# Altium schematic sheet [10] - FPGA BANK 0, XADC.SchDoc("[10] - FPGA BANK 0, XADC")
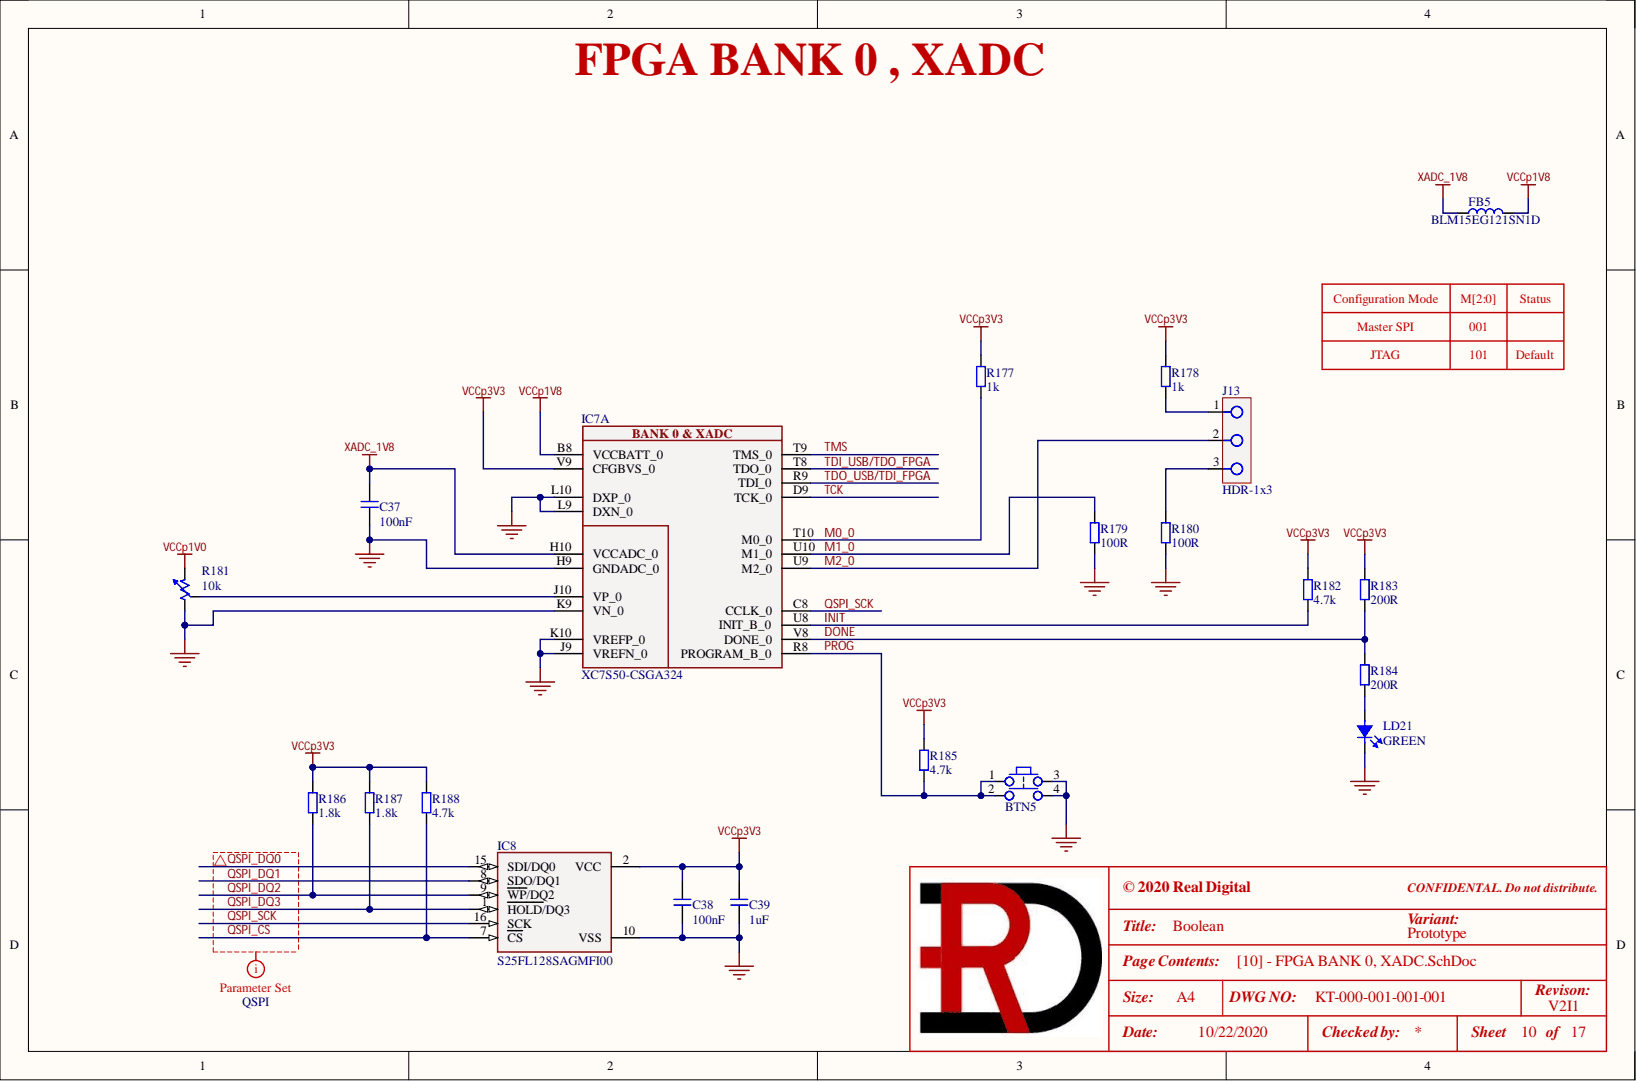
[diagram p0: [10] - FPGA BANK 0, XADC.SchDoc]

## Parts
BTN5  PTA-142  [PTA-142]  (value not drawn)
C37  100nF  [C0402]
C38  100nF  [C0402]
C39  1uF  [C0603]
FB5  BLM15EG121SN1D  [BLM15]
IC7  XC7S50-CSGA324  [CSG324]  (part A)
IC8  S25FL128SAGMFI00  [SOIC-16W]
J13  HDR-1x3  [HDR1X3]
LD21  GREEN  [LD0603_Green]
R177  1k  [R0402]
R178  1k  [R0402]
R179  100R  [R0402]
R180  100R  [R0402]
R181  10k  [R09N]
R182  4.7k  [R0402]
R183  200R  [R0402]
R184  200R  [R0402]
R185  4.7k  [R0402]
R186  1.8k  [R0402]
R187  1.8k  [R0402]
R188  4.7k  [R0402]

## Nets
GND: BTN5.3 BTN5.4 C37.1 C38.1 C39.1 IC7.H9(GNDADC_0) IC7.J9(VREFN_0) IC7.K9(VN_0) IC7.K10(VREFP_0) IC7.L9(DXN_0) IC7.L10(DXP_0) IC8.10(VSS) LD21.K R179.1 R180.1 R181.1
VCCp1V0: R181.3
VCCp1V8: FB5.2 IC7.B8(VCCBATT_0)
VCCp3V3: C38.2 C39.2 IC7.V9(CFGBVS_0) IC8.2(VCC) R177.2 R178.2 R182.2 R183.2 R185.2 R186.2 R187.2 R188.2
XADC_1V8: C37.2 FB5.1 IC7.H10(VCCADC_0)
DONE: IC7.V8(DONE_0) R183.1 R184.2
INIT: IC7.U8(INIT_B_0) R182.1
M0_0: IC7.T10(M0_0) R177.1
M1_0: IC7.U10(M1_0) R179.2
M2_0: IC7.U9(M2_0) J13.2
PROG: BTN5.1 BTN5.2 IC7.R8(PROGRAM_B_0) R185.1
QSPI_CS: IC8.7(CS) R188.1
QSPI_DQ0: IC8.15(SDI/DQ0)
QSPI_DQ1: IC8.8(SDO/DQ1)
QSPI_DQ2: IC8.9(WP/DQ2) R186.1
QSPI_DQ3: IC8.1(HOLD/DQ3) R187.1
QSPI_SCK: IC7.C8(CCLK_0) IC8.16(SCK)
TCK: IC7.D9(TCK_0)
TDI_USB/TDO_FPGA: IC7.T8(TDO_0)
TDO_USB/TDI_FPGA: IC7.R9(TDI_0)
TMS: IC7.T9(TMS_0)
NetIC7_J10: IC7.J10(VP_0) R181.2
NetJ13_1: J13.1 R178.1
NetJ13_3: J13.3 R180.2
NetLD21_A: LD21.A R184.1

## Library data of the parts
BTN5 = Tact Switch: SWITCH TACTILE SPST-NO, 4.50mm x 4.50mm; Manufacturer 1: Pacer Technology Co., Ltd; Manufacturer 2 Part Number: TSXH-2GL; .Creator: RV; C P/N: 153-0059; LoadStatus: Load; Category: Tactile Switches; Component Kind: Standard; Library Name: KT-RealDigital.IntLib; Manufacturer 1 Part Number: PTA-142
C37 C38 = GRM155R71E104KE14J: CAP CER 0.1uF 25V X7R 0402; Manufacturer 1: Murata Electronics; .Checked_PCB: AC; .Creator: RV; C P/N: RD-111-0006; LoadStatus: Load; Tolerance: 10%; Category: Capacitors; Component Kind: Standard; Library Name: KT-RealDigital.IntLib; Manufacturer 1 Part Number: GRM155R71E104KE14J; Temperature Coefficient: X7R; Voltage - Rated: 25V; .Checked_SCH: AC
C39 = GCJ188R71E105KA01D: CAP CER 1uF 25V X7R 0603; Manufacturer 1: Murata Electronics; .Checked_PCB: AC; .Creator: RV; C P/N: 111-0602; LoadStatus: Load; Tolerance: 10%; Category: Capacitors; Component Kind: Standard; Library Name: KT-RealDigital.IntLib; Manufacturer 1 Part Number: GCJ188R71E105KA01D; Temperature Coefficient: X7R; Voltage - Rated: 25V; .Checked_SCH: AC
FB5 = BLM15EG121SN1D: FERRITE BEAD 120 OHM 0402 1LN; Manufacturer 1: Murata Electronics; .Checked_PCB: AC; .Creator: RV; C P/N: 131-0493; LoadStatus: Load; Category: Ferrite Beads; Component Kind: Standard; Library Name: KT-RealDigital.IntLib; Manufacturer 1 Part Number: BLM15EG121SN1D; .Checked_SCH: AC
IC7 = XC7S50-CSGA324: FPGA 210 I/O 324CSBGA; Manufacturer 1: Xilinx; .Creator: RV; C P/N: RD-126-0003-CD; LoadStatus: Load; Category: IC; Component Kind: Standard; Library Name: KT-RealDigital.IntLib; Manufacturer 1 Part Number: XC7S50-CSGA324
IC8 = S25FL128SAGMFI00: IC FLASH 128M SPI 133MHZ 16SOIC; Manufacturer 1: Cypress Semiconductor Corp; .Checked_PCB: AC; .Creator: RV; C P/N: 126-0862; LoadStatus: Load; Category: IC; Component Kind: Standard; Library Name: KT-RealDigital.IntLib; Manufacturer 1 Part Number: S25FL128SAGMFI00; .Checked_SCH: AC
J13 = HDR-1x3: Header 2.54mm, 1x3; Manufacturer 1: Centronic Precision Electronic; Manufacturer 1 Part Number: H6-80-03; .Checked_PCB: AC; .Creator: RV; C P/N: 163-0035; LoadStatus: Load; Category: Header; Component Kind: Standard; Library Name: KT-RealDigital.IntLib; .Checked_SCH: AC
LD21 = LED_GREEN: LED GREEN 0603; Manufacturer 1: Everlight Electronics CO., LTD; Manufacturer 1 Part Number: 19-21SYGC/S530-E4/TR8; .Checked_PCB: AC; .Creator: RV; C P/N: 121-0006; LoadStatus: Load; Category: Led; Component Kind: Standard; Library Name: KT-RealDigital.IntLib; .Checked_SCH: AC
R177 R178 = RC0402JR-071KP: RES SMD 1K OHM 5% 1/16W 0402; Manufacturer 1: Yageo; .Checked_PCB: AC; .Creator: RV; C P/N: 101-0050; LoadStatus: Load; Category: Resistors; Component Kind: Standard; Library Name: KT-RealDigital.IntLib; Manufacturer 1 Part Number: RC0402JR-071KP; Tolerance: 5%; .Checked_SCH: AC
R179 R180 = RC0402JR-07100RL: RES SMD 100 OHM 5% 1/16W 0402; Manufacturer 1: Yageo; .Checked_PCB: AC; .Creator: RV; C P/N: 101-0035; LoadStatus: Load; Category: Resistors; Component Kind: Standard; Library Name: KT-RealDigital.IntLib; Manufacturer 1 Part Number: RC0402JR-07100RL; Tolerance: 5%; .Checked_SCH: AC
R181 = R09N-B10K: POTENTIOMETER 10k, R09N-B10K/10φX1.8T; Manufacturer 1: Song Huei Electric CO. LTD; .Creator: RV; C P/N: 101-0210-1; LoadStatus: Load; Category: Potentiometer; Component Kind: Standard; Library Name: KT-RealDigital.IntLib; Manufacturer 1 Part Number: R09N-B10K/10φX1.8T
R182 R185 R188 = RC0402FR-074K7P: RES SMD 4.7K OHM 1% 1/16W 0402; Manufacturer 1: Yageo; .Checked_PCB: AC; .Creator: RV; C P/N: 101-0129; LoadStatus: Load; Category: Resistors; Component Kind: Standard; Library Name: KT-RealDigital.IntLib; Manufacturer 1 Part Number: RC0402FR-074K7P; Tolerance: 1%; .Checked_SCH: AC
R183 R184 = RC0402JR-07200RL: RES SMD 200 OHM 5% 1/16W 0402; Manufacturer 1: Yageo; .Checked_PCB: AC; .Creator: RV; C P/N: 101-0045; LoadStatus: Load; Category: Resistors; Component Kind: Standard; Library Name: KT-RealDigital.IntLib; Manufacturer 1 Part Number: RC0402JR-07200RL; Tolerance: 5%; .Checked_SCH: AC
R186 R187 = RC0402JR-071K8L: RES SMD 1.8K OHM 5% 1/16W 0402; Manufacturer 1: Yageo; .Checked_PCB: AC; .Creator: RV; C P/N: 101-0946; LoadStatus: Load; Category: Resistors; Component Kind: Standard; Library Name: KT-RealDigital.IntLib; Manufacturer 1 Part Number: RC0402JR-071K8L; Tolerance: 5%; .Checked_SCH: AC
